# Altium schematic sheet 2) Main Power.SchDoc("2) Main Power")
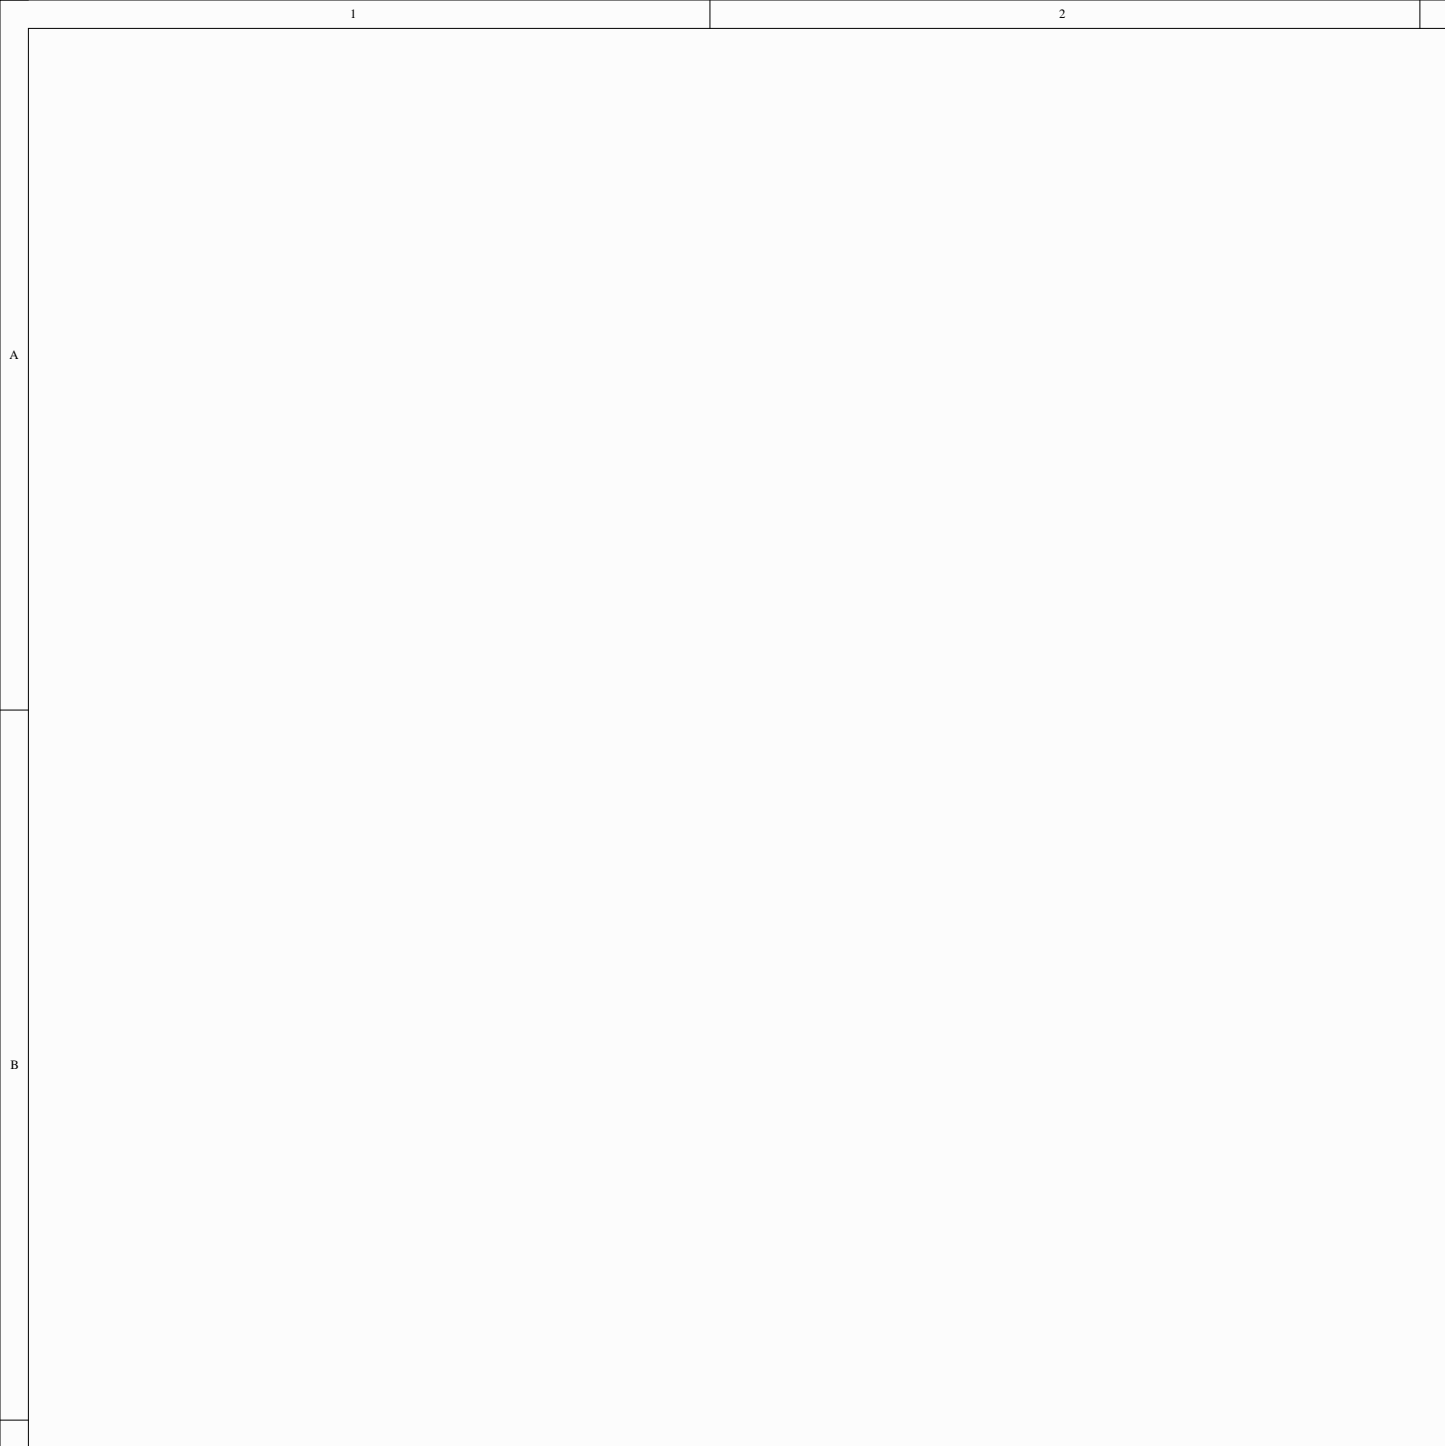
[diagram p0: 2) Main Power.SchDoc, top left]
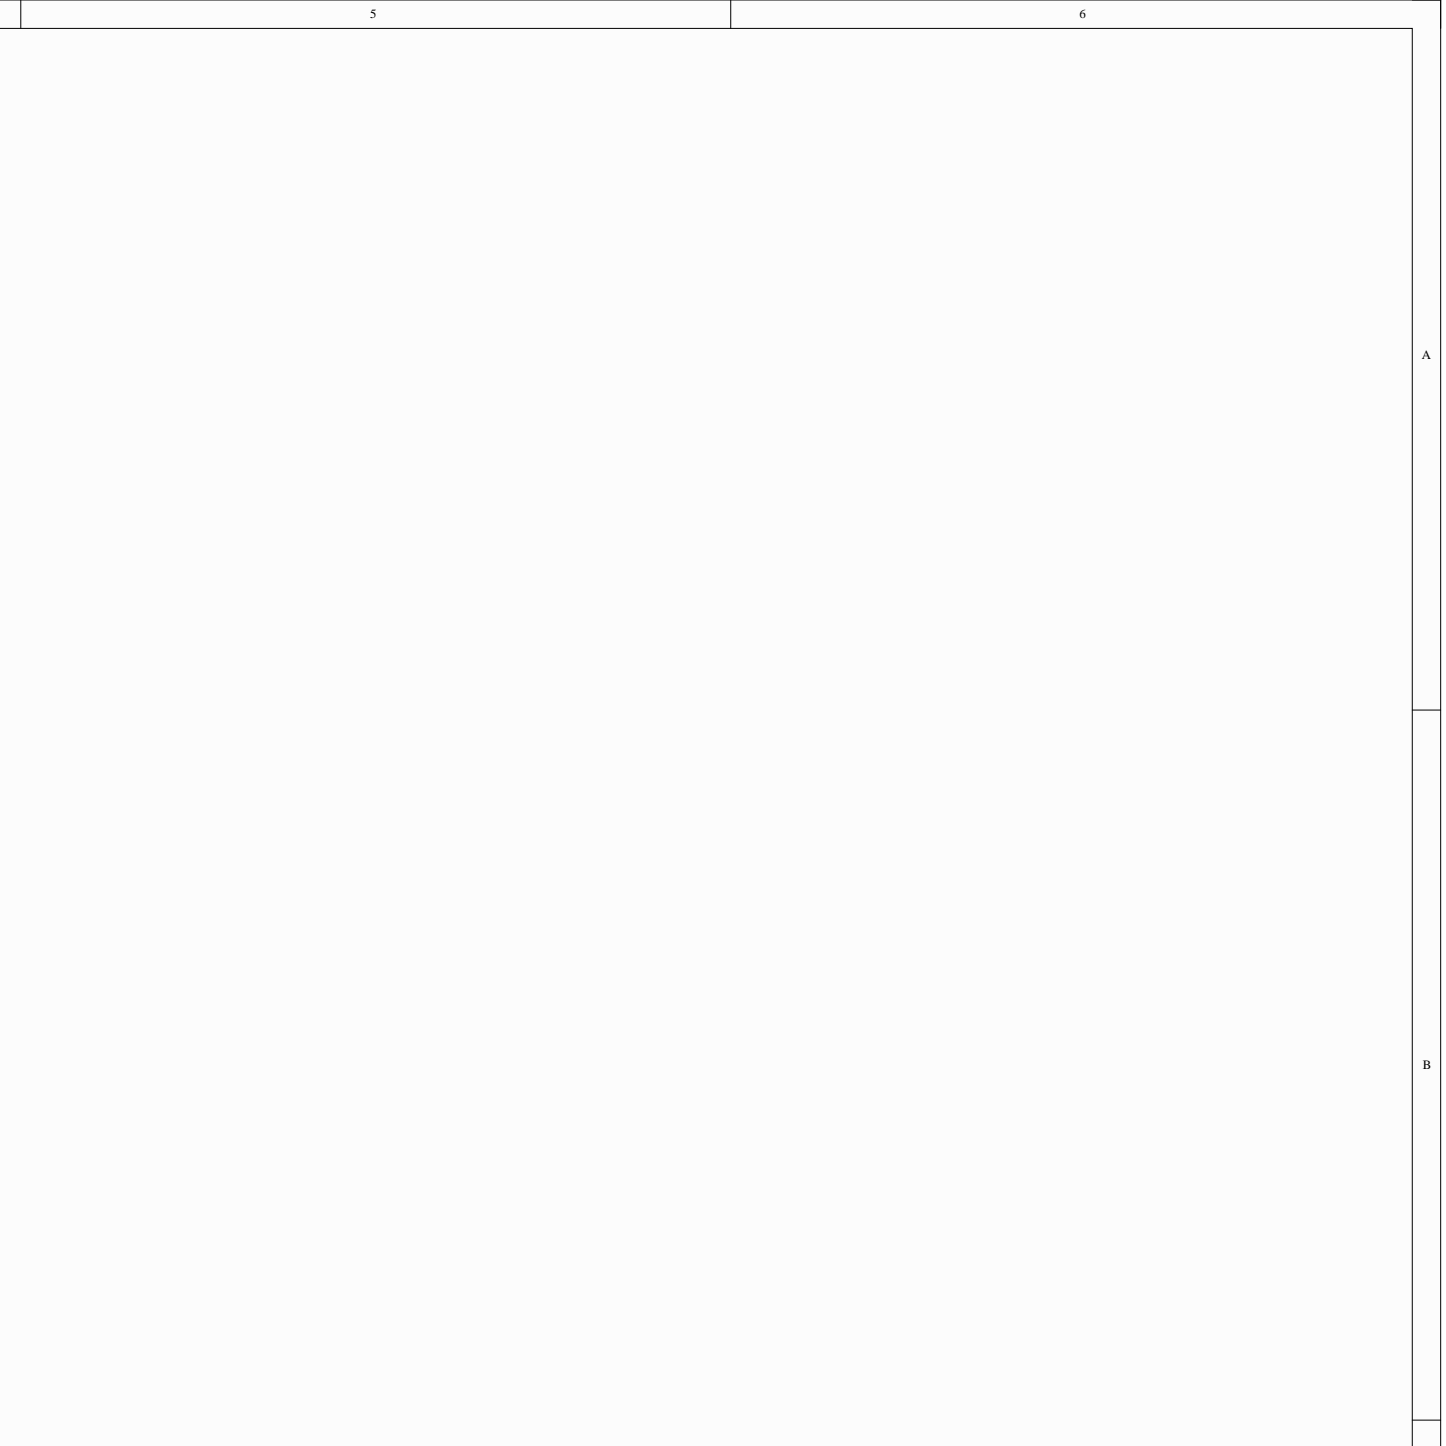
[diagram p1: 2) Main Power.SchDoc, top right]
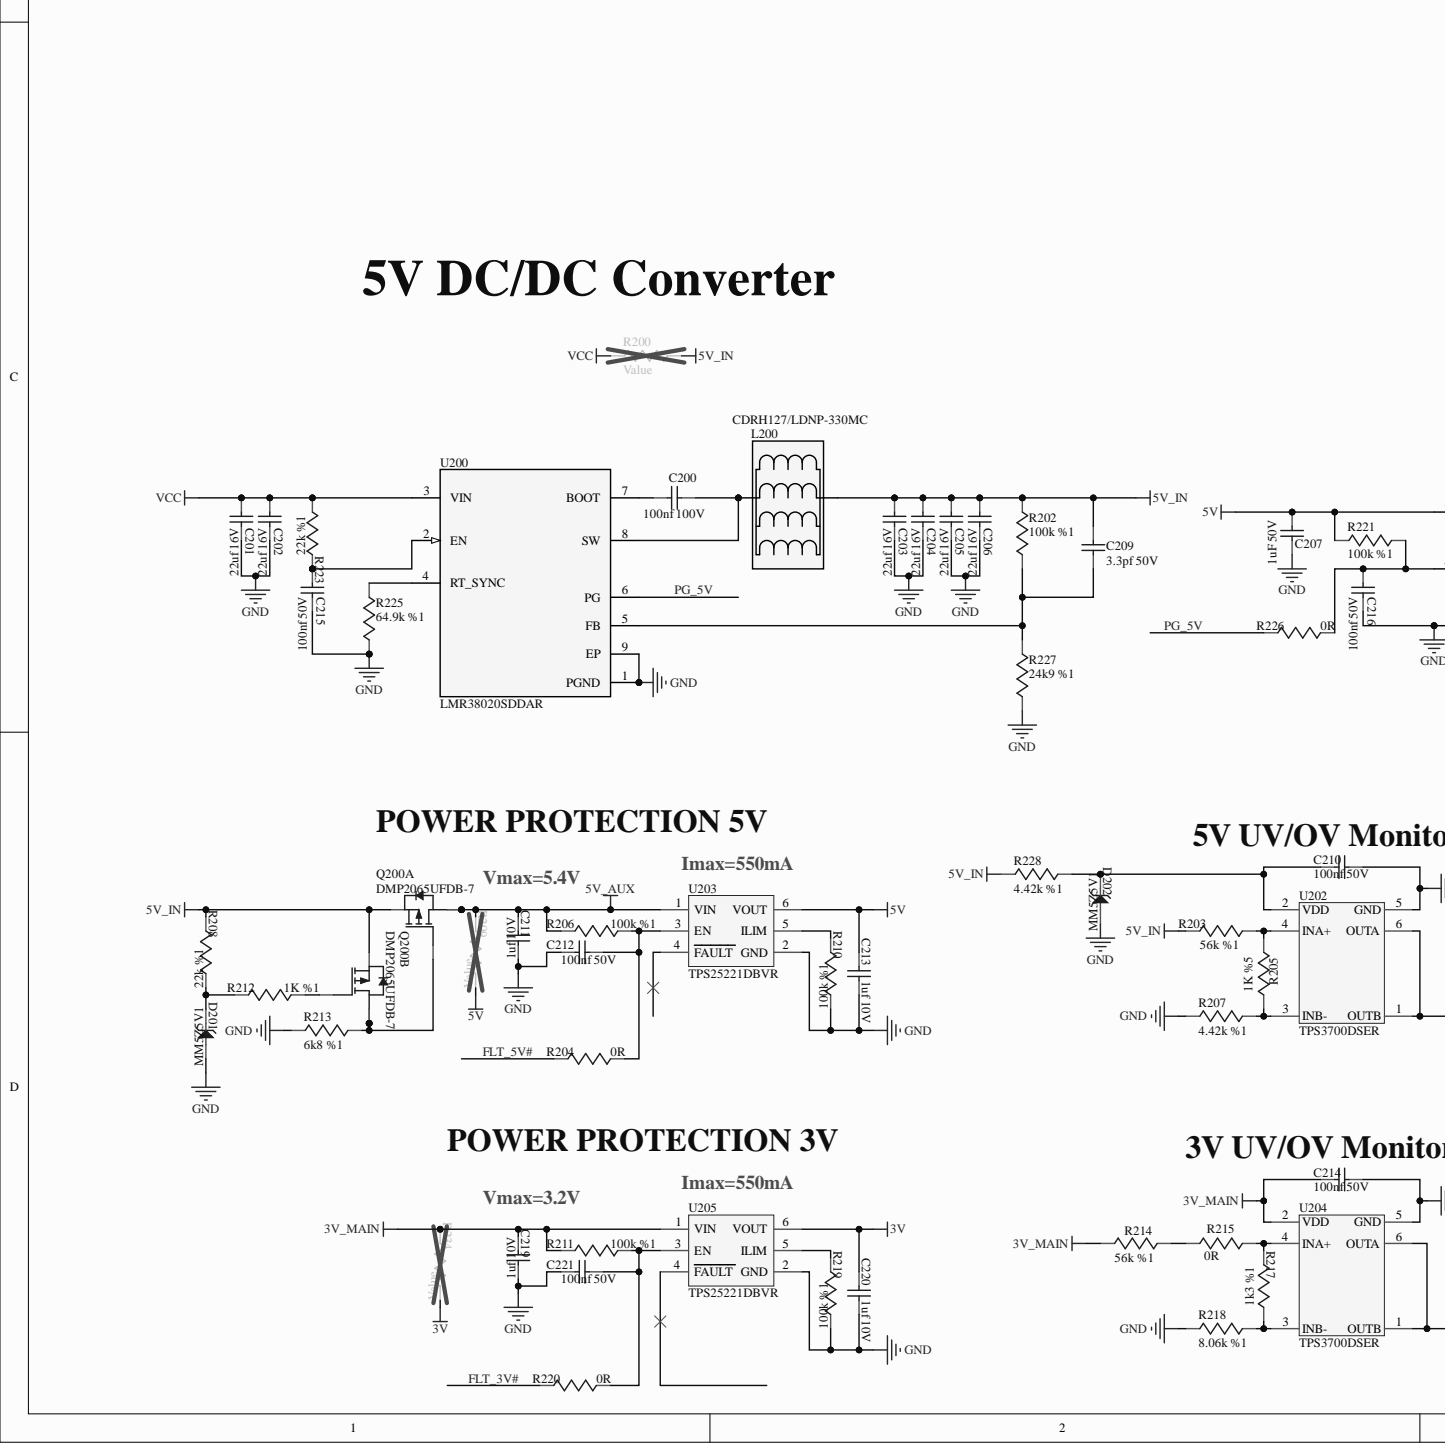
[diagram p2: 2) Main Power.SchDoc, bottom left]
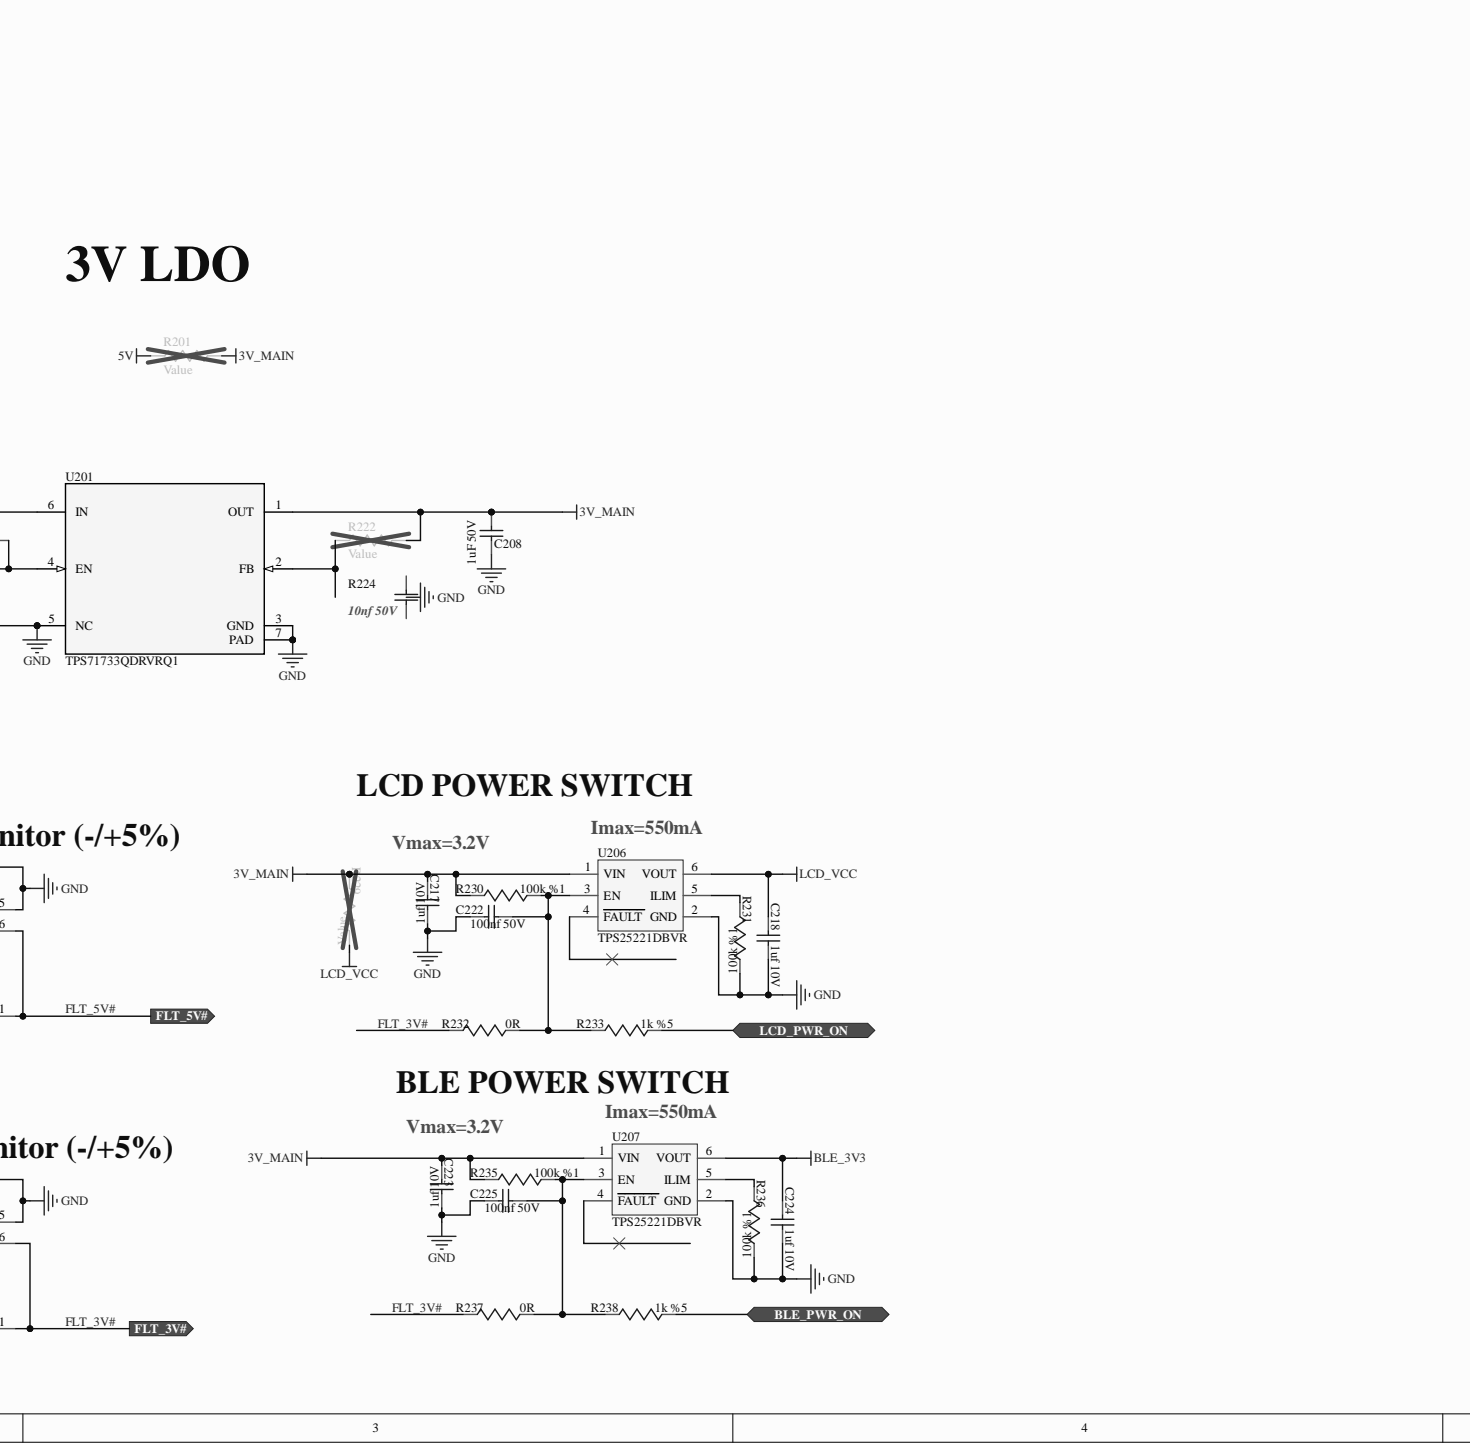
[diagram p3: 2) Main Power.SchDoc, bottom centre]
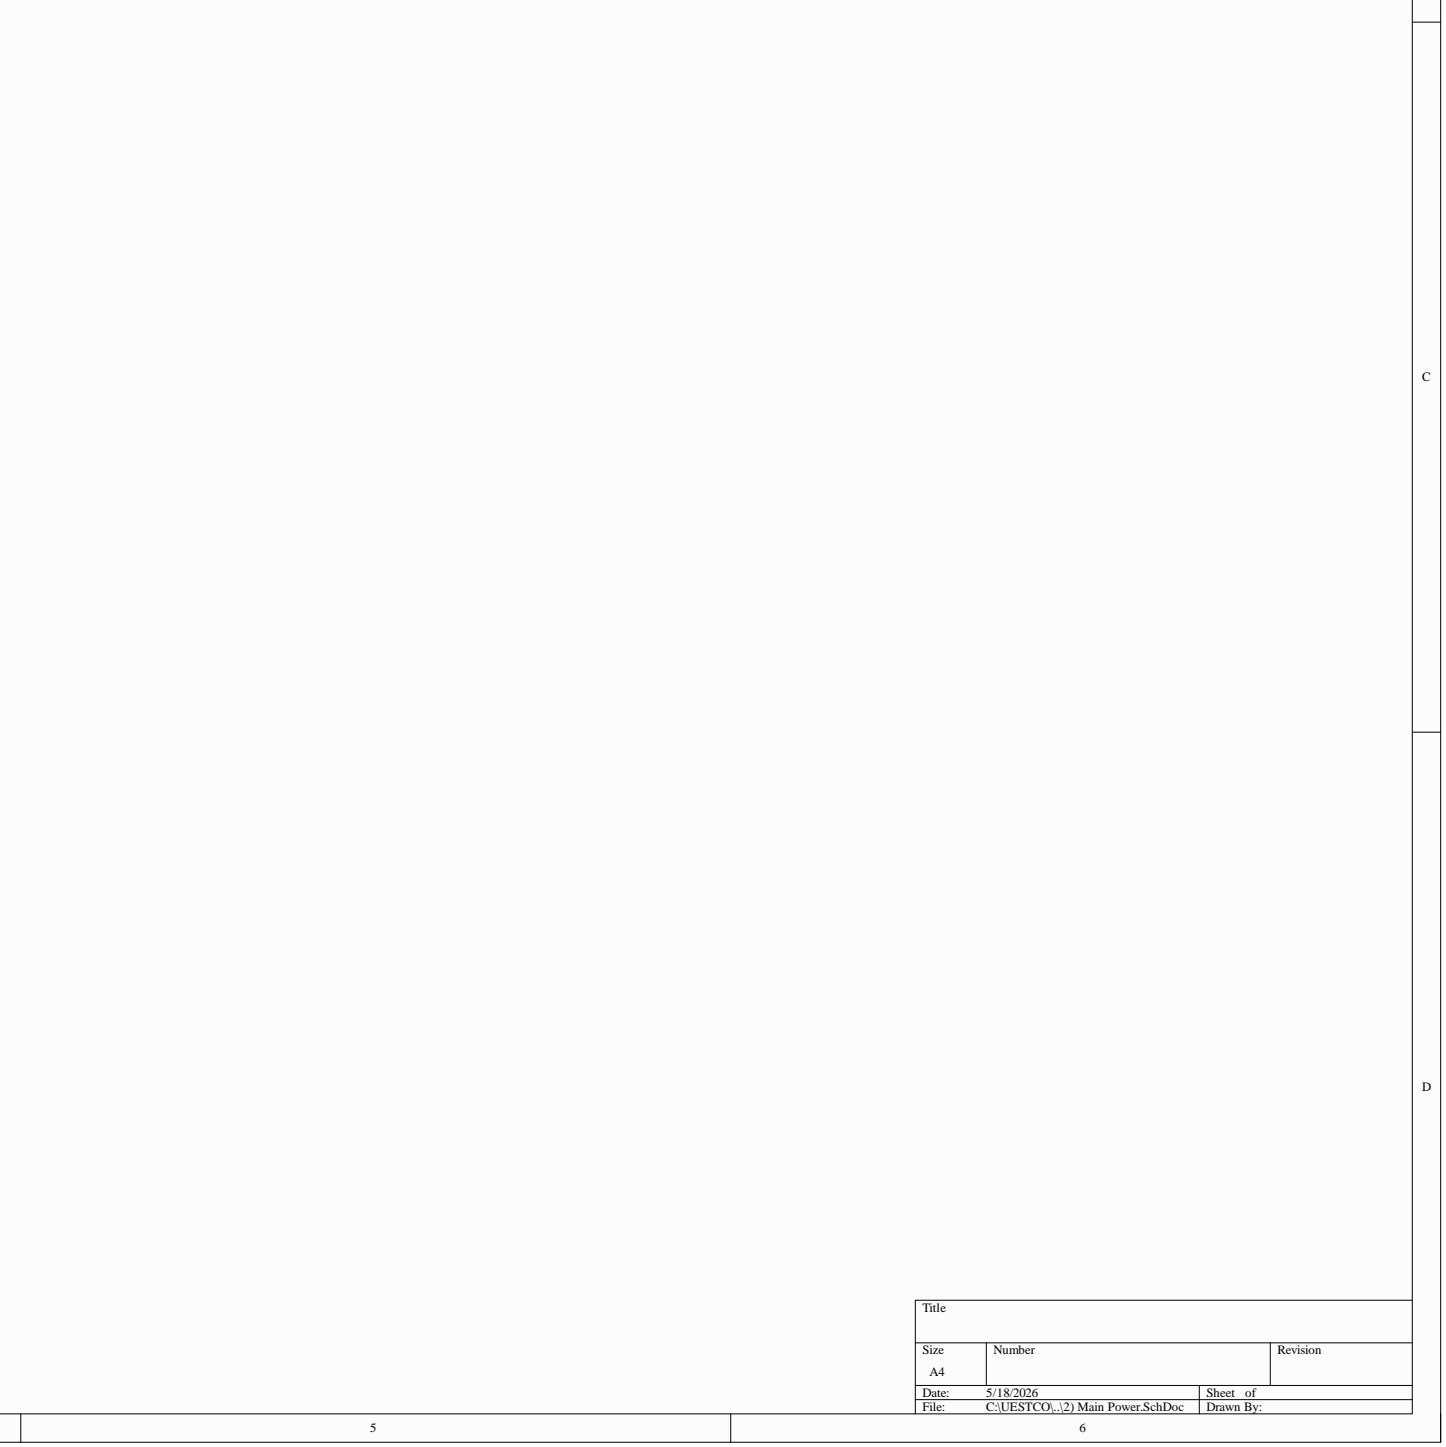
[diagram p4: 2) Main Power.SchDoc, bottom right]

## Parts
C200  100nf 100V  [C0402]
C201  22uf 16V  [C0603]
C202  22uf 16V  [C0603]
C203  22uf 16V  [C0603]
C204  22uf 16V  [C0603]
C205  22uf 16V  [C0603]
C206  22uf 16V  [C0603]
C207  1uF 50V  [C0402]
C208  1uF 50V  [C0402]
C209  3.3pf 50V  [C0402]
C210  100nf 50V  [C0402]
C211  1uf 10V  [C0402]
C212  100nf 50V  [C0402]
C213  1uf 10V  [C0402]
C214  100nf 50V  [C0402]
C215  100nf 50V  [C0402]
C216  100nf 50V  [C0402]
C217  1uf 10V  [C0402]
C218  1uf 10V  [C0402]
C219  1uf 10V  [C0402]
C220  1uf 10V  [C0402]
C221  100nf 50V  [C0402]
C222  100nf 50V  [C0402]
C223  1uf 10V  [C0402]
C224  1uf 10V  [C0402]
C225  100nf 50V  [C0402]
D201  MM5Z5V1  [SOD-523]
D202  MM5Z5V1  [SOD-523]
L200  CDRH127/LDNP-330MC  [SMD2_CDRH127_SUM]
Q200  DMP2065UFDB-7  [6-DFN2020 (2.08X2.08)]  (parts A,B)
R200  0R  [R0402]
R201  0R  [R0402]
R202  100k %1  [R0402]
R203  56k %1  [R0402]
R204  0R  [R0402]
R205  1K %5  [R0402]
R206  100k %1  [R0402]
R207  4.42k %1  [R0402]
R208  22k %1  [R0402]
R209  0R  [R0402]
R210  100k %1  [R0402]
R211  100k %1  [R0402]
R212  1K %1  [R0402]
R213  6k8 %1  [R0402]
R214  56k %1  [R0402]
R215  0R  [R0402]
R217  1k3 %1  [R0402]
R218  8.06k %1  [R0402]
R219  100k %1  [R0402]
R220  0R  [R0402]
R221  100k %1  [R0402]
R222  100k %1  [R0402]
R223  22k %1  [R0402]
R224
R224
R224
R224
R224  10nf 50V  [C0402]
R225  64.9k %1  [R0402]
R226  0R  [R0402]
R227  24k9 %1  [R0402]
R228  4.42k %1  [R0402]
R229  0R  [R0402]
R230  100k %1  [R0402]
R231  100k %1  [R0402]
R232  0R  [R0402]
R233  1k %5  [R0402]
R234  0R  [R0402]
R235  100k %1  [R0402]
R236  100k %1  [R0402]
R237  0R  [R0402]
R238  1k %5  [R0402]
U200  LMR38020SDDAR  [DDA0008E-IPC_A]
U201  TPS71733QDRVRQ1  [DRV0006A]
U202  TPS3700DSER  [6-WSON (1.5x1.5)]
U203  TPS25221DBVR  [SOT23_6]
U204  TPS3700DSER  [6-WSON (1.5x1.5)]
U205  TPS25221DBVR  [SOT23_6]
U206  TPS25221DBVR  [SOT23_6]
U207  TPS25221DBVR  [SOT23_6]

## Ports
BLE_PWR_ON, FLT_3V#, FLT_5V#, LCD_PWR_ON

## Nets
3V: C220.1 R234.1 U205.6(VOUT)
3V_MAIN: C208.2 C214.2 C217.2 C219.2 C223.2 R201.1 R211.1 R214.1 R222.1 R229.2 R230.1 R234.2 R235.1 U201.1(OUT) U204.2(VDD) U205.1(VIN) U206.1(VIN) U207.1(VIN)
5V: C207.2 C213.1 R201.2 R209.1 R221.2 U201.6(IN) U203.6(VOUT)
5V_AUX: C211.2 Q200.6 Q200.7 R206.1 R209.2 U203.1(VIN)
5V_IN: C203.2 C204.2 C205.2 C206.2 C209.1 L200.2 Q200.1 Q200.4 R200.1 R202.2 R203.1 R208.2 R228.2
BLE_3V3: C224.1 U207.6(VOUT)
GND: C201.1 C202.1 C203.1 C204.1 C205.1 C206.1 C207.1 C208.1 C210.1 C211.1 C212.1 C213.2 C214.1 C215.1 C216.1 C217.1 C218.2 C219.1 C220.2 C221.1 C222.1 C223.1 C224.2 C225.1 D201.2 D202.2 R207.2 R210.1 R213.2 R218.2 R219.1 R224.1 R225.1 R227.1 R231.1 R236.1 U200.1(PGND) U200.9(EP) U201.3(GND) U201.5(NC) U201.7(PAD) U202.5(GND) U203.2(GND) U204.5(GND) U205.2(GND) U206.2(GND) U207.2(GND)
LCD_VCC: C218.1 R229.1 U206.6(VOUT)
VCC: C201.2 C202.2 R200.2 R223.2 U200.3(VIN)
BLE_PWR_ON: R238.2 ; port BLE_PWR_ON
FLT_3V#: R220.1 R232.1 R237.1 U204.1(OUTB) U204.6(OUTA) ; port FLT_3V#
FLT_5V#: R204.1 U202.1(OUTB) U202.6(OUTA) ; port FLT_5V#
LCD_PWR_ON: R233.2 ; port LCD_PWR_ON
PG_5V: R226.1 U200.6(PG)
NetC200_1: C200.1 L200.1 U200.8(SW)
NetC200_2: C200.2 U200.7(BOOT)
NetC209_2: C209.2 R202.1 R227.2 U200.5(FB)
NetC210_2: C210.2 D202.1 R228.1 U202.2(VDD)
NetC212_2: C212.2 R204.2 R206.2 U203.3(EN)
NetC215_2: C215.2 R223.1 U200.2(EN)
NetC216_2: C216.2 R221.1 R226.2 U201.4(EN)
NetC221_2: C221.2 R211.2 R220.2 U205.3(EN)
NetC222_2: C222.2 R230.2 R232.2 R233.1 U206.3(EN)
NetC225_2: C225.2 R235.2 R237.2 R238.1 U207.3(EN)
NetD201_1: D201.1 R208.1 R212.1
NetQ200_2: Q200.2 Q200.3 Q200.8 R213.1
NetQ200_5: Q200.5 R212.2
NetR203_2: R203.2 R205.1 U202.4(INA+)
NetR205_2: R205.2 R207.1 U202.3(INB-)
NetR210_2: R210.2 U203.5(ILIM)
NetR214_2: R214.2 R215.1
NetR215_2: R215.2 R217.2 U204.4(INA+)
NetR217_1: R217.1 R218.1 U204.3(INB-)
NetR219_2: R219.2 U205.5(ILIM)
NetR222_2: R222.2 R224.2 U201.2(FB)
NetR225_2: R225.2 U200.4(RT_SYNC)
NetR231_2: R231.2 U206.5(ILIM)
NetR236_2: R236.2 U207.5(ILIM)

Not connected: U203 4(FAULT); U205 4(FAULT); U206 4(FAULT); U207 4(FAULT)

## Library data of the parts
C200 = CAP 100nf 50V %10 0402: CAP CER 0.1UF 50V X5R 0402; Manufacturer Part Number: GRM155R62A104KE14D; Component Kind: Standard; Library Name: PCBGSM910G2.SCHLIB; Value: 100nf 100V
C201-C206 = CAP 22uf 10V %10 0603: CAP CER 22UF 10V X5R 0603; Manufacturer Part Number: CL10A226MO7JZNC; Component Kind: Standard; Library Name: CAP LIB.SchLib; Value: 22uf 16V
C207 C208 = CAP 4.7uf 10V %20 0402: CAP CER 4.7UF 10V X5R 0402; Manufacturer Part Number: GRM155R61H105KE05D; Component Kind: Standard; Library Name: CAP LIB.SchLib; Value: 1uF 50V
C209 = CAP 3.3pf 50V ±0.25pF 0402: CAP CER 3.3PF 50V C0G/NP0 0402; Manufacturer Part Number: GRM1555C1H3R3CA01D; Component Kind: Standard; Library Name: CAP LIB.SchLib; Value: 3.3pf 50V
C210 C212 C214-C216 C221 C222 C225 = CAP 100nf 50V %10 0402: CAP CER 0.1UF 50V X5R 0402; Manufacturer Part Number: CC0402KRX5R9BB104; Component Kind: Standard; Value: 100nf 50V
  C210 C212 C214 C221 C222 C225: Library Name: PCBGSM910G2.SCHLIB
  C215 C216: Library Name: CAP LIB.SchLib
C211 C213 C217-C220 C223 C224 = CAP 1uf 10V %10 0402: CAP CER 1UF 10V X5R 0402; Manufacturer Part Number: CL05A105KP5NNNC; Component Kind: Standard; Library Name: PCBGSM910G2.SCHLIB; Value: 1uf 10V
D201 D202 = Zener MM5Z5V1ST1G: DIODE ZENER 5.1V 500MW SOD523; Component Kind: Standard; Library Name: PCBGSM910G2.SCHLIB; Manufacturer Part Number: MM5Z5V1ST1G; Value: MM5Z5V1
L200 = CDRH127LDNP-330MC: Description; Manufacturer_Name: Sumida; Type: Fixed Inductors; Component Kind: Standard; Library Name: CDRH127LDNP-330MC.SchLib; Manufacturer_Part_Number: CDRH127/LDNP-330MC; Copyright: Copyright (C) 2025 Ultra Librarian. All rights reserved.
Q200 = TRANS DMP2065UFDB-7 DUAL: MOSFET 2P-CH 20V 4.5A 6UDFN; Component Kind: Standard; Library Name: PCBGSM910G2.SCHLIB; Manufacturer Part Number: DMP2065UFDB-7; Value: DMP2065UFDB-7
R200 R201 R204 R209 R215 R220 R226 R229 R232 R234 R237 = RES 0R 1/16W 0402: RES SMD 0 OHM JUMPER 1/16W 0402; Manufacturer Part Number: RC0402JR-070RL; Component Kind: Standard; Value: 0R
  R200 R201: Library Name: RES LIB.SchLib
  R204 R209 R215 R220 R226 R229 R232 R234 R237: Library Name: PCBGSM910G2.SCHLIB
R202 R206 R210 R211 R219 R221 R222 R230 R231 R235 R236 = RES 100K %1 1/16W 0402: RES SMD 100K OHM 1% 1/16W 0402; Manufacturer Part Number: RC0402FR-07100KL; Component Kind: Standard; Value: 100k %1
  R202 R221 R222: Library Name: RES LIB.SchLib
  R206 R210 R211 R219 R230 R231 R235 R236: Library Name: PCBGSM910G2.SCHLIB
R203 R214 = RES 294k %1 1/16W 0402: RES SMD 56K OHM 0.1% 1/16W 0402; Manufacturer Part Number: ERA-2AEB563X; Component Kind: Standard; Library Name: PCBGSM910G2.SCHLIB; Value: 56k %1
R205 = RES 1K %5 1/16W 0402: RES SMD 1K OHM 5% 1/16W 0402; Manufacturer Part Number: RC0402JR-071KL; Component Kind: Standard; Library Name: PCBGSM910G2.SCHLIB; Value: 1K %5
R207 R228 = RES 2k49 %1 1/16W 0402: RES 4.42K OHM 1% 1/16W 0402; Manufacturer Part Number: RC0402FR-074K42L; Component Kind: Standard; Library Name: PCBGSM910G2.SCHLIB; Value: 4.42k %1
R208 R223 = RES 22k %1 1/16W 0402: RES 22K OHM 1% 1/16W 0402; Manufacturer Part Number: RC0402FR-0722KL; Component Kind: Standard; Value: 22k %1
  R208: Library Name: PCBGSM910G2.SCHLIB
  R223: Library Name: RES LIB.SchLib
R212 = RES 1K %1 1/16W 0402: RES SMD 1K OHM 1% 1/16W 0402; Manufacturer Part Number: RC0402FR-071KL; Component Kind: Standard; Library Name: PCBGSM910G2.SCHLIB; Value: 1K %1
R213 = RES 6K8 %1 1/16W 0402: RES 6.8K OHM 1% 1/16W 0402; Manufacturer Part Number: RC0402FR-076K8L; Component Kind: Standard; Library Name: PCBGSM910G2.SCHLIB; Value: 6k8 %1
R217 = RES 1k24 %1 1/16W 0402: RES SMD 1.3K OHM 0.1% 1/16W 0402; Manufacturer Part Number: ERA-2ARB132X; Component Kind: Standard; Library Name: PCBGSM910G2.SCHLIB; Value: 1k3 %1
R218 = RES 2k49 %1 1/16W 0402: RES SMD 8.06KOHM 0.1% 1/16W 0402; Manufacturer Part Number: ERA-2AEB8061X; Component Kind: Standard; Library Name: PCBGSM910G2.SCHLIB; Value: 8.06k %1
R224 = CAP 10nf 50V %10 0402: CAP CER 10000PF 50V X7R 0402; Manufacturer Part Number: CC0402KRX7R9BB103; Component Kind: Standard; Library Name: PCBGSM910G2.SCHLIB; Value: 10nf 50V
R225 = RES 24k9 %1 1/16W 0402: RES 24.9K OHM 1% 1/16W 0402; Manufacturer Part Number: CRCW040264K9FKED; Component Kind: Standard; Library Name: RES LIB.SchLib; Value: 64.9k %1
R227 = RES 24k9 %1 1/16W 0402: RES 24.9K OHM 1% 1/16W 0402; Manufacturer Part Number: RC0402FR-0724K9L; Component Kind: Standard; Library Name: RES LIB.SchLib; Value: 24k9 %1
R233 R238 = RES 100K %1 1/16W 0402: RES SMD 100K OHM 1% 1/16W 0402; Manufacturer Part Number: RC0402JR-071KL; Component Kind: Standard; Library Name: PCBGSM910G2.SCHLIB; Value: 1k %5
U200 = LMR38020SDDAR: SIMPLE SWITCHERÂ® power converter 4.2-V to 80-V, 2-A, synchronous buck with 40-ÂµA IQ 8-SO PowerPAD -40 to 150; Manufacturer_Name: Texas Instruments; Component Kind: Standard; Library Name: LMR38020SDDAR.SchLib; Manufacturer_Part_Number: LMR38020SDDAR; RefDes: RefDes; TYPE: TYPE; Copyright: Copyright (C) 2026 Ultra Librarian. All rights reserved.
U201 = TPS71733QDRVRQ1: Automotive 150-mA, high-PSRR, low-IQ, low-dropout voltage regulator with enable 6-WSON -40 to 125; Manufacturer_Name: Texas Instruments; Component Kind: Standard; Library Name: TPS71733QDRVRQ1.SchLib; Manufacturer_Part_Number: TPS71733QDRVRQ1; RefDes: RefDes; TYPE: TYPE; Copyright: Copyright (C) 2025 Ultra Librarian. All rights reserved.
U202 U204 = IC LOGIC TPS3700DSER: IC COMPARATOR 1 WINDW 6WSON; Component Kind: Standard; Library Name: PCBGSM910G2.SCHLIB; Manufacturer Part Number: TPS3700DSER; Value: TPS3700DSER
U203 U205-U207 = USB Load SW TPS25221DBVR: IC CURRENT SWITCH 2A SOT23-6; Component Kind: Standard; Library Name: PCBGSM910G2.SCHLIB; Manufacturer Part Number: TPS25221DBVR; Value: TPS25221DBVR
